# Altium schematic sheet Voltage_Regulator.SchDoc
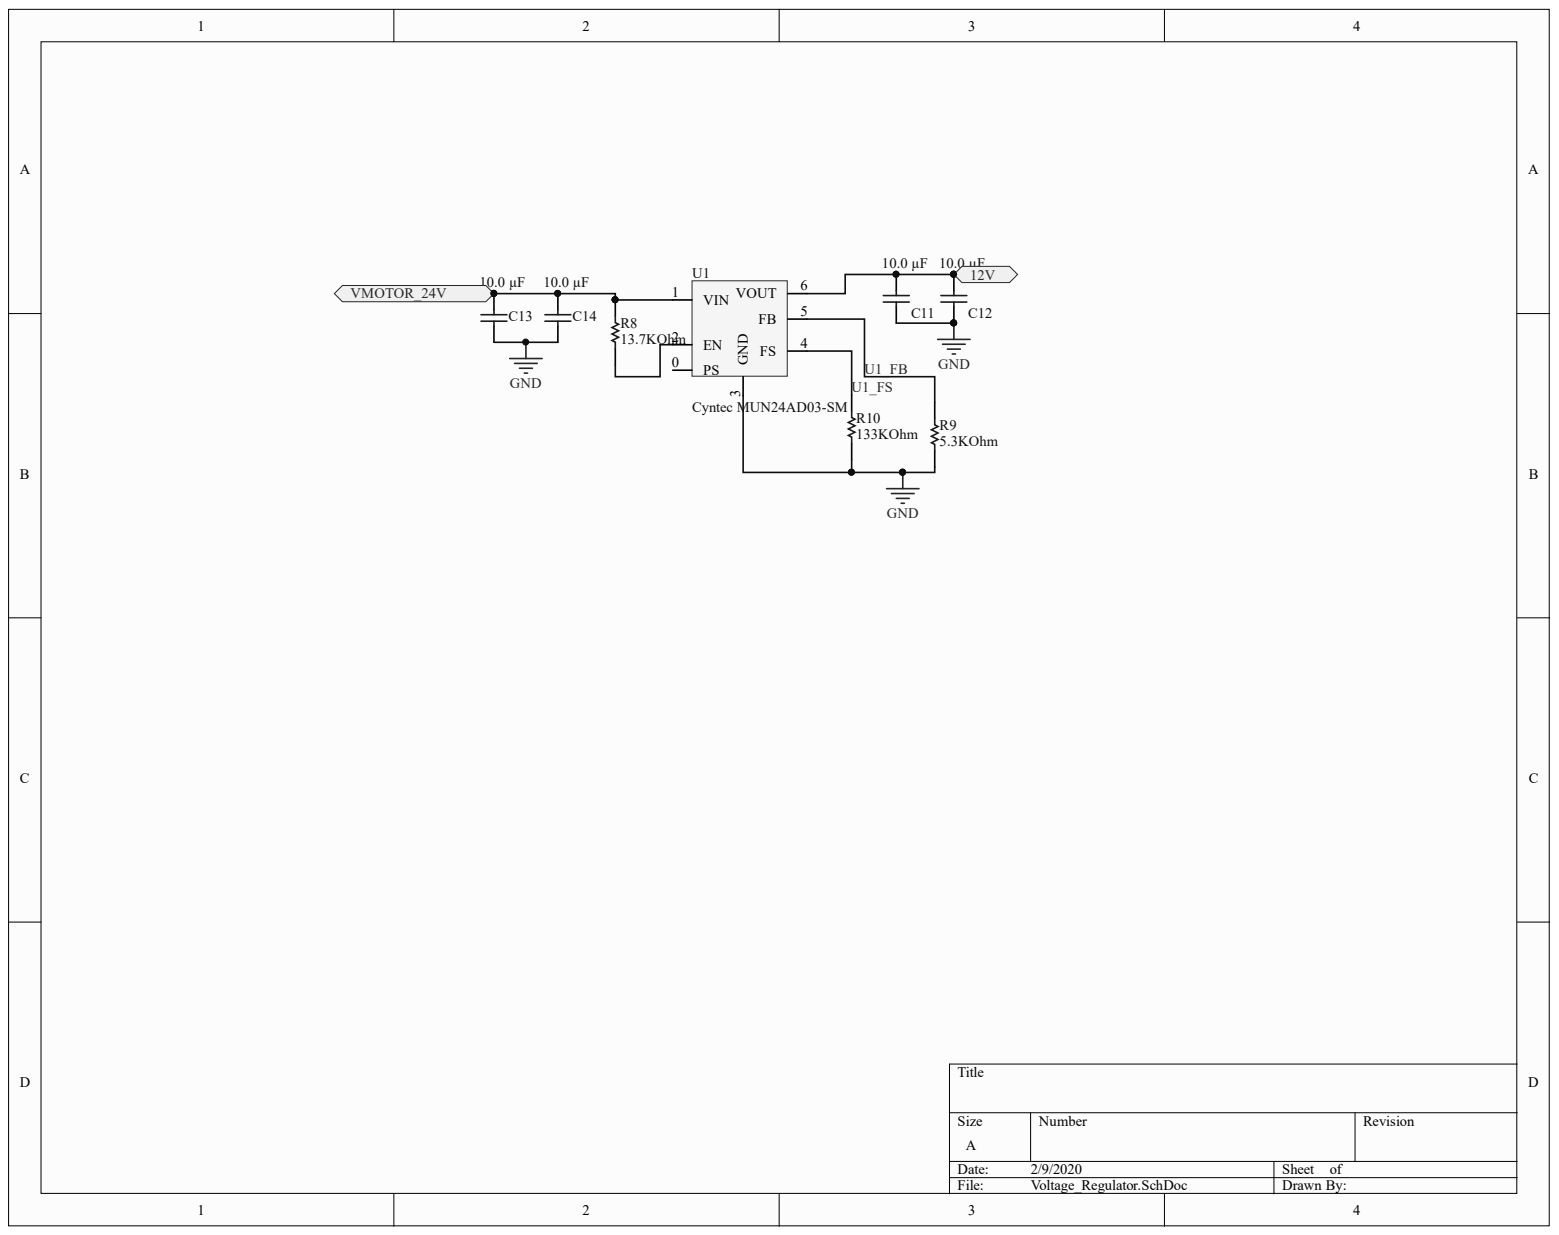
[diagram p0: Voltage_Regulator.SchDoc]

## Parts
C11  Capacitance: 10.0 µF  [CAPC3216X170X48LL20T23]  (hidden comment: CL31A106MBHNNNE)
C12  Capacitance: 10.0 µF  [CAPC3216X170X48LL20T23]  (hidden comment: CL31A106MBHNNNE)
C13  Capacitance: 10.0 µF  [CAPC3216X170X48LL20T23]  (hidden comment: CL31A106MBHNNNE)
C14  Capacitance: 10.0 µF  [CAPC3216X170X48LL20T23]  (hidden comment: CL31A106MBHNNNE)
R8  13.7KOhm  [RESC1608X06N]
R9  5.3KOhm  [RESC1608X06N]
R10  133KOhm  [RESC1608X06N]
U1  Cyntec MUN24AD03-SM  [QFN80P600X600X350_HS-21N]

## Ports
12V, VMOTOR_24V

## Nets
GND: C11.1 C12.1 C13.1 C14.1 R9.1 R10.1 U1.3(GND)
12V: C11.2 C12.2 U1.6(VOUT) ; port 12V
U1_FB: R9.2 U1.5(FB)
U1_FS: R10.2 U1.4(FS)
VMOTOR_24V: C13.2 C14.2 R8.2 U1.1(VIN) ; port VMOTOR_24V
NetR8_1: R8.1 U1.2(EN)

Not connected: U1 0(PS)

## Library data of the parts
C11-C14 = CMP-32a5ffa1fad6ed62-4: 1206 10 uF 50 V ±20% Tolerance X5R Multilayer Ceramic Chip Capacitor; Voltage Rating (DC): 50.0 V; Case/Package: 1206; Component Kind: Standard; Dielectric Characteristic: X5R; Library Name: CommunityVault; OctopartID: 32a5ffa1fad6ed62; Simulation: SIM-c8wbmthqgd7w7aiuayie-1
R8 = CMP-c5280d07caed1aef-1: Res Thick Film 0603 13.7K Ohm 1% 0.1W(1/10W) ±100ppm/C Pad SMD Automotive T/R; Manufacturer Part Number: RMCF0603FT13K7; Lead-Free Status: Lead Free; Power Rating: 100 mW; Resistance: 13.7 k?; Case/Package: 0603; Component Kind: Standard; Library Name: FavoritesLibrary; Mounting Style: Surface Mount; OctopartID: c5280d07caed1aef; Octopart URL: https://octopart.com/rmcf0603ft13k7-stackpole+electronics-19212963; Packaging: Tape & Reel (TR); Resistance Tolerance: ±1%; RoHS: Compliant
R9 = CMP-b9b7723a10b36670-1: Res Thin Film 0603 5.3K Ohm 0.1% 1/8W ±25ppm/°C Molded SMD SMD Paper T/R; Manufacturer Part Number: TNPW06035K30BEEA; Lead-Free Status: Lead Free; Power Rating: 100 mW; Resistance: 5.30 k?; Temperature Coefficient: -25.0 ppm/°C to 25.0 ppm/°C; Voltage Rating (DC): 50.0 V; Case/Package: 0603; Component Kind: Standard; Library Name: CommunityVault; Mounting Style: Surface Mount; OctopartID: b9b7723a10b36670; Octopart URL: https://octopart.com/tnpw06035k30beea-vishay-42437730; Packaging: Reel; Resistance Tolerance: ±0.1%; RoHS: Compliant
R10 = CMP-4f38871740c090ff-1: Res Thick Film 0603 133K Ohm 1% 0.1W(1/10W) ±100ppm/C Pad SMD Automotive T/R; Manufacturer Part Number: RMCF0603FT133K; Lead-Free Status: Lead Free; Power Rating: 100 mW; Resistance: 133 k?; Case/Package: 0603; Component Kind: Standard; Library Name: FavoritesLibrary; Mounting Style: Surface Mount; OctopartID: 4f38871740c090ff; Octopart URL: https://octopart.com/rmcf0603ft133k-stackpole+electronics-19212958; Packaging: Tape & Reel (TR); Resistance Tolerance: ±1%; RoHS: Compliant
U1 = CMP-93fa5f47b2814c3d-6: Module DC-DC 1-OUT 5V to 12V 3A SMD 25-Pin QFN T/R; Component Kind: Standard; Library Name: CommunityVault
